# Altium schematic sheet USB_RF_CC1101.SchDoc(USB_RF_CC1101)
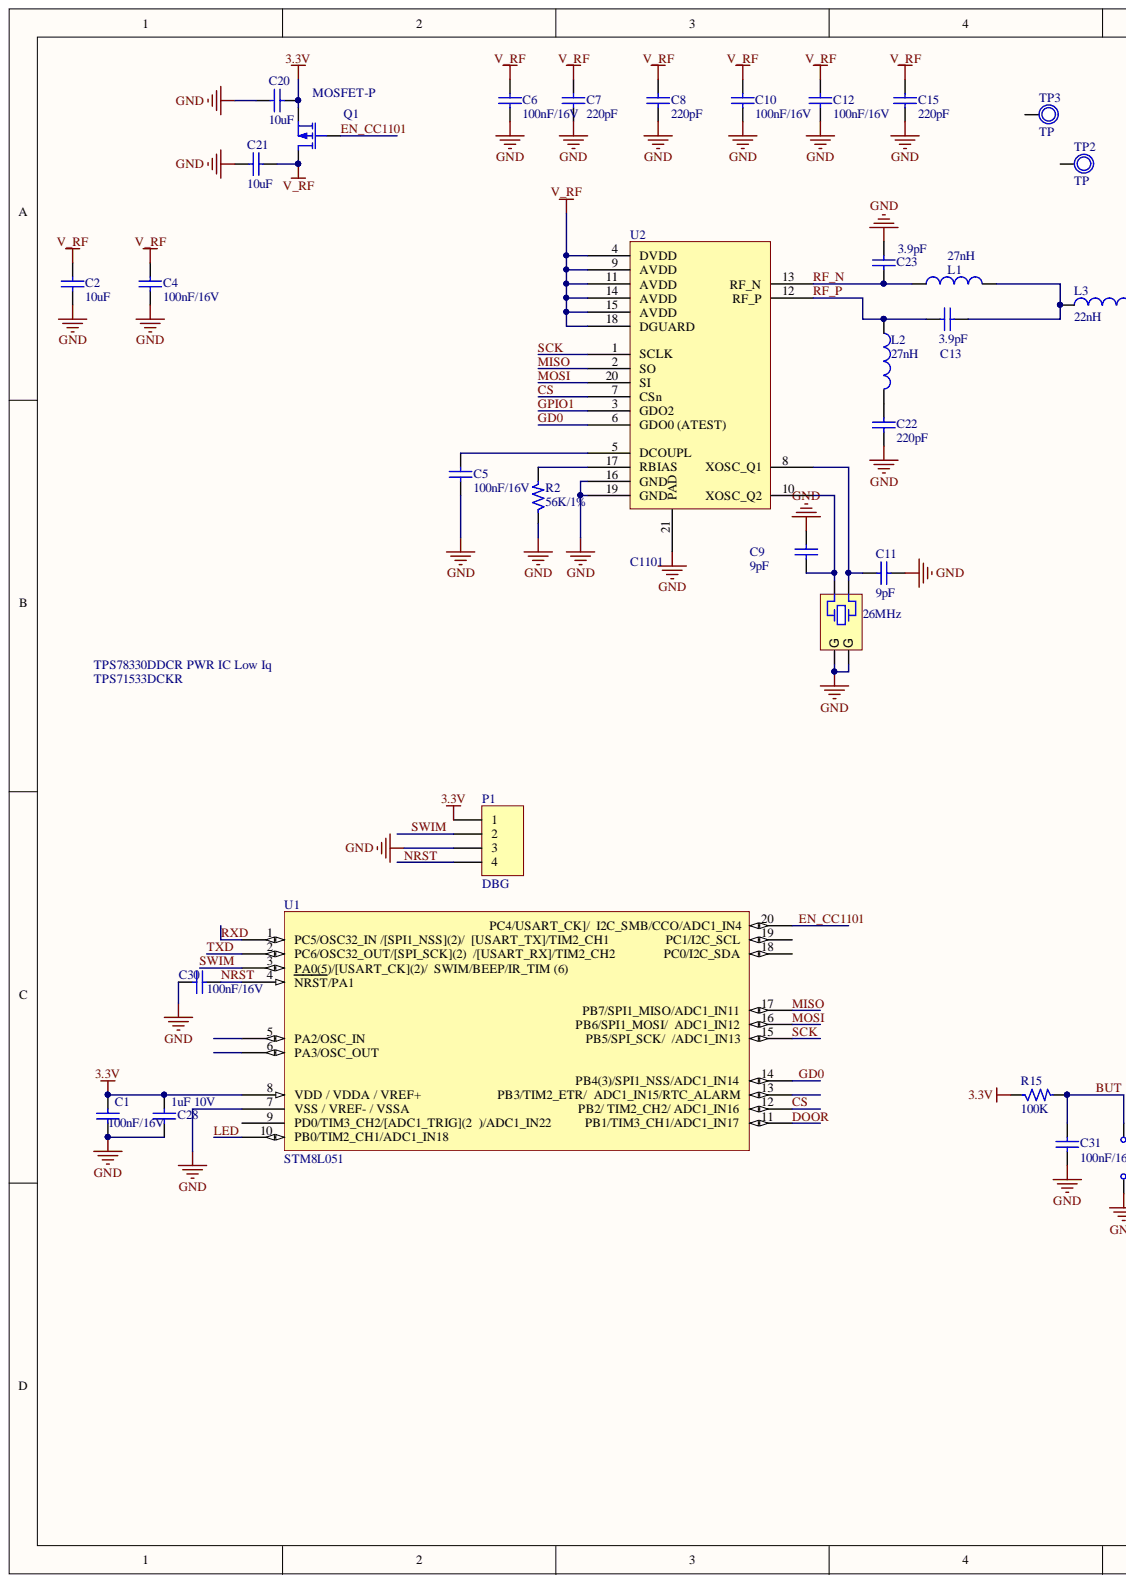
[diagram p0: USB_RF_CC1101.SchDoc, left]
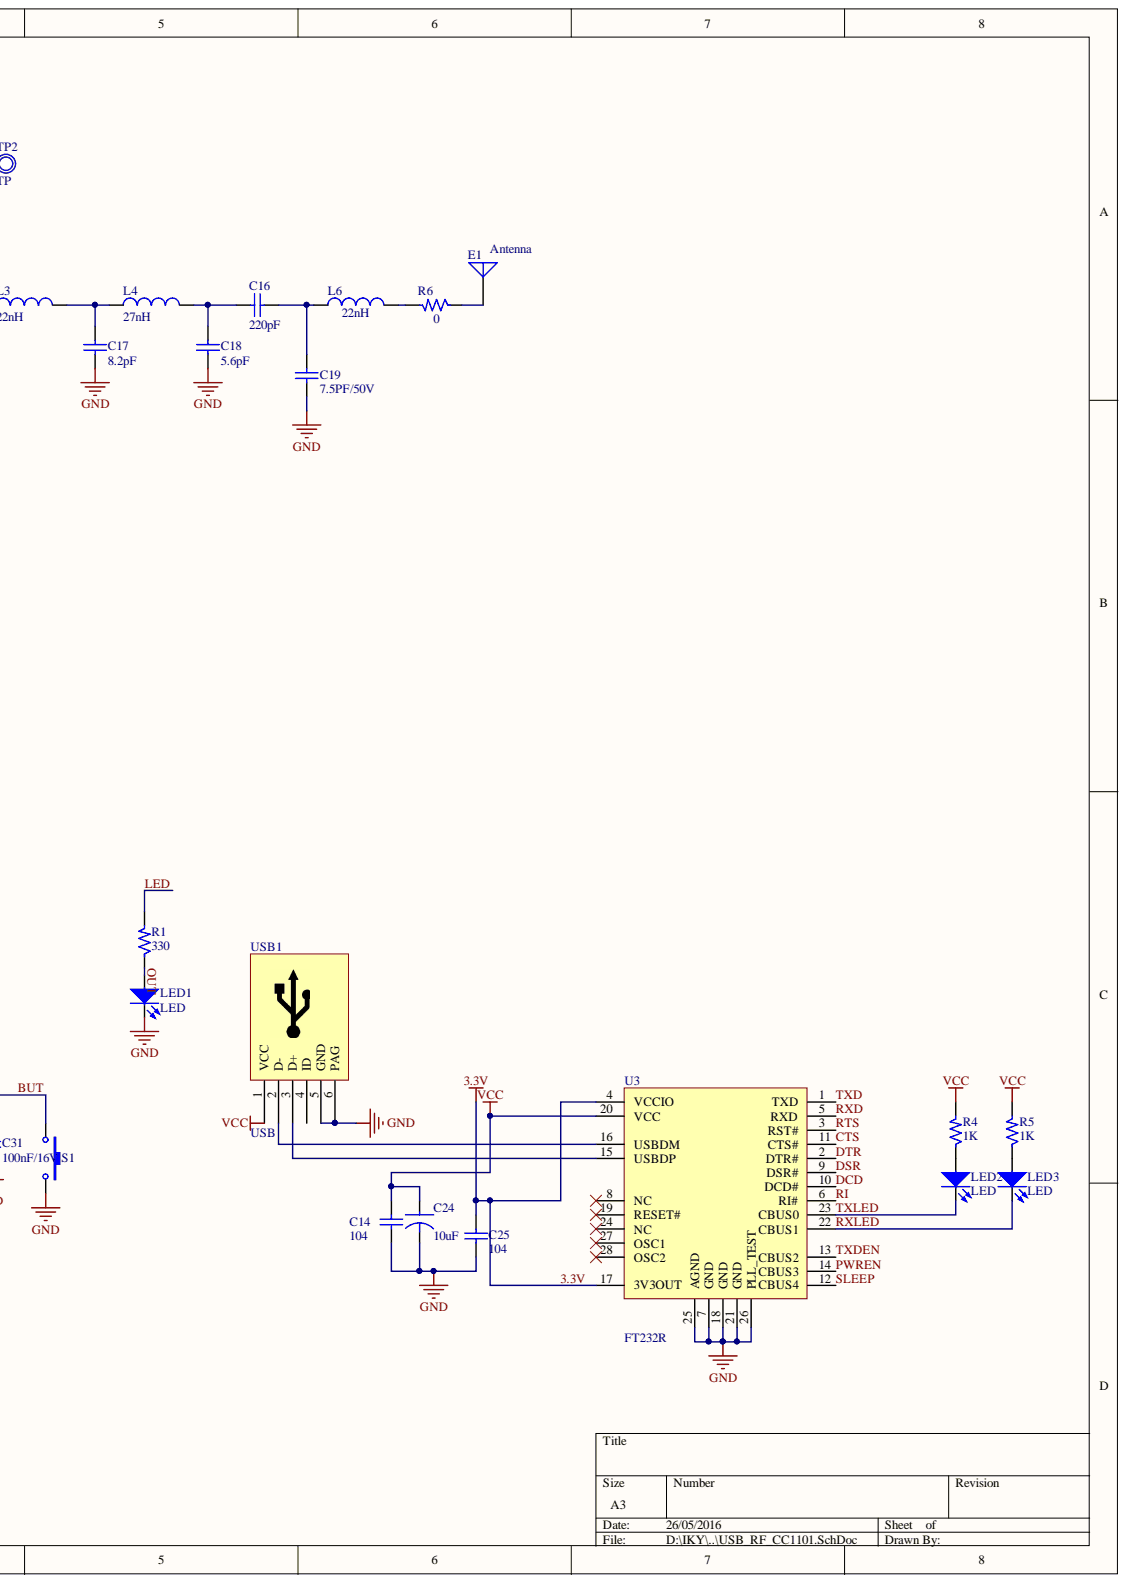
[diagram p1: USB_RF_CC1101.SchDoc, right]

## Parts
C1  100nF/16V  [CAP SMD 0402.CVH]
C2  10uF  [CAP SMD 0603.tdx]
C4  100nF/16V  [CAP SMD 0402 TI.TDX]
C5  100nF/16V  [CAP SMD 0402 TI.TDX]
C6  100nF/16V  [CAP SMD 0402 TI.TDX]
C7  220pF  [CAP SMD 0402 TI.TDX]
C8  220pF  [CAP SMD 0402 TI.TDX]
C9  9pF  [CAP SMD 0402 TI.TDX]
C10  100nF/16V  [CAP SMD 0402 TI.TDX]
C11  9pF  [CAP SMD 0402 TI.TDX]
C12  100nF/16V  [CAP SMD 0402 TI.TDX]
C13  3.9pF  [CAP SMD 0402 TI.TDX]
C14  104  [CAP SMD 0402.tdx]
C15  220pF  [CAP SMD 0402 TI.TDX]
C16  220pF  [CAP SMD 0402 TI.TDX]
C17  8.2pF  [CAP SMD 0402 TI.TDX]
C18  5.6pF  [CAP SMD 0402 TI.TDX]
C19  7.5PF/50V  [CAP SMD 0402 TI.TDX]
C20  10uF  [CAP SMD 0603.tdx]
C21  10uF  [CAP SMD 0603.tdx]
C22  220pF  [CAP SMD 0402 TI.TDX]
C23  3.9pF  [CAP SMD 0402 TI.TDX]
C24  10uF  [CAP SMD 0805.CVH]
C25  104  [CAP SMD 0402.tdx]
C28  1uF 10V  [CAP SMD 0805.CVH]
C30  100nF/16V  [CAP SMD 0402.CVH]
C31  100nF/16V  [CAP SMD 0402.CVH]
E1  Antenna  [Anten 433MHZ PULSE.TDX]
L1  27nH  [INDUCTOR SMD 0402 TI.TDX]
L2  27nH  [INDUCTOR SMD 0402 TI.TDX]
L3  22nH  [INDUCTOR SMD 0402 TI.TDX]
L4  27nH  [INDUCTOR SMD 0402 TI.TDX]
L6  22nH  [INDUCTOR SMD 0402 TI.TDX]
LED1  LED  [LED SMD 0603 RED.CVH]
LED2  LED  [LED SMD 0805 GRE.CVH]
LED3  LED  [LED SMD 0805 RED.CVH]
P1  DBG  [HEADER 4PIN.TDX]
Q1  MOSFET-P  [SOT23-3.CVH]
R1  330  [RESISTOR SMD 0402.CVH]
R2  56K/1%  [RESISTOR SMD 0402 TI.TDX]
R4  1K  [RESISTOR SMD 0402.tdx]
R5  1K  [RESISTOR SMD 0402.tdx]
R6  0  [RESISTOR SMD 0402.CVH]
R15  100K  [RESISTOR SMD 0402.CVH]
S1  SW  [BUTTON SMD 4PIN_PTS810.TDX]  (value not drawn)
TP2  TP  [TEST POINT SMD.CVH]
TP3  TP  [TEST POINT SMD.CVH]
U1  STM8L051  [TSSOP 20.CVH]
U2  C1101  [QFN-20_CC1101.TDX]
U3  FT232R  [SSOP 28.CVH]
USB1  USB  [USB MINI SMD.CVH]
Y1  26MHz  [CRYSTAL SMD 4 PIN 3.2×2.5×0.75.TDX]

## Nets
GND: C1.2 C2.2 C4.2 C5.1 C6.2 C7.2 C8.2 C9.2 C10.2 C11.1 C12.2 C14.1 C15.2 C17.1 C18.1 C19.2 C20.1 C21.2 C22.1 C23.1 C24.2 C25.2 C28.1 C30.2 C31.2 LED1.2 P1.3 R2.1 S1.2 U1.7(VSS_/_VREF-_/_VSSA) U2.16(GND) U2.19(GND) U2.21(PAD) U3.7(GND) U3.18(GND) U3.21(GND) U3.25(AGND) U3.26(PLL_TEST) USB1.5(GND) USB1.6(PAG) Y1.2(G) Y1.4(G)
V_RF: C2.1 C4.1 C6.1 C7.1 C8.1 C10.1 C12.1 C15.1 C21.1 Q1.3 U2.4(DVDD) U2.9(AVDD) U2.11(AVDD) U2.14(AVDD) U2.15(AVDD) U2.18(DGUARD)
VCC: C14.2 C24.1 R4.2 R5.2 U3.20(VCC) USB1.1(VCC)
3.3V: C1.1 C20.2 C25.1 C28.2 P1.1 Q1.2 R15.1 U1.8(VDD_/_VDDA_/_VREF+) U3.4(VCCIO) U3.17(3V3OUT)
BUT: C31.1 R15.2 S1.1
CS: U1.12(PB2/_TIM2_CH2/_ADC1_IN16) U2.7(CSn)
CTS: U3.11(CTS#)
DCD: U3.10(DCD#)
DOOR: U1.11(PB1/TIM3_CH1/ADC1_IN17)
DSR: U3.9(DSR#)
DTR: U3.2(DTR#)
EN_CC1101: Q1.1 U1.20(I2C_SMB/CCO/ADC1_IN4)
GD0: U1.14(PB4(3)/SPI1_NSS/ADC1_IN14) U2.6(GDO0_(ATEST))
GPIO1: U2.3(GDO2)
LED: R1.2 U1.10(PB0/TIM2_CH1/ADC1_IN18)
MISO: U1.17(PB7/SPI1_MISO/ADC1_IN11) U2.2(SO)
MOSI: U1.16(ADC1_IN12) U2.20(SI)
NRST: C30.1 P1.4 U1.4(NRST/PA1)
OUT: LED1.1 R1.1
PWREN: U3.14(CBUS3)
RF_N: C23.2 L1.1 U2.13(RF_N)
RF_P: C13.2 L2.1 U2.12(RF_P)
RI: U3.6(RI#)
RTS: U3.3(RST#)
RXD: U1.1(PC5/OSC32_IN_/[SPI1_NSS](2)/) U3.5(RXD)
RXLED: LED3.2 U3.22(CBUS1)
SCK: U1.15(/ADC1_IN13) U2.1(SCLK)
SLEEP: U3.12(CBUS4)
SWIM: P1.2 U1.3(PA0(5)/[USART_CK](2)/)
TXD: U1.2(PC6/OSC32_OUT/[SPI_SCK](2)) U3.1(TXD)
TXDEN: U3.13(CBUS2)
TXLED: LED2.2 U3.23(CBUS0)
NetC5_2: C5.2 U2.5(DCOUPL)
NetC9_1: C9.1 U2.10(XOSC_Q2) Y1.1
NetC11_2: C11.2 U2.8(XOSC_Q1) Y1.3
NetC13_1: C13.1 L1.2 L3.1
NetC16_1: C16.1 C19.1 L6.1
NetC16_2: C16.2 C18.2 L4.2
NetC17_2: C17.2 L3.2 L4.1
NetC22_2: C22.2 L2.2
NetE1_1: E1.1 R6.1
NetL6_2: L6.2 R6.2
NetLED2_1: LED2.1 R4.1
NetLED3_1: LED3.1 R5.1
NetR2_2: R2.2 U2.17(RBIAS)
NetU3_15: U3.15(USBDP) USB1.3(D+)
NetU3_16: U3.16(USBDM) USB1.2(D-)

Not connected: TP2 1; TP3 1; U1 5(PA2/OSC_IN) 6(PA3/OSC_OUT) 9(PD0/TIM3_CH2/[ADC1_TRIG](2) 13(ADC1_IN15/RTC_ALARM) 18(PC0/I2C_SDA) 19(PC1/I2C_SCL); U3 8(NC) 19(RESET#) 24(NC) 27(OSC1) 28(OSC2); USB1 4(ID)

## Library data of the parts
C1 C2 C4-C23 C25 C30 C31 = Capacitor NP: Ceramic capacitor; Manufacturer: Yageo; Value: 100nF/50V; Component Kind: Standard; Publisher: Cao Van Huong, vanhuongcao@gmail.com
  C1 C30 C31: Library Name: Altium Library.CVH.SchLib
  C2 C4-C13 C15-C23: Library Name: Altium Library.CVH.SchLib
  C14 C25: Library Name: Altium Library.CVH-TOANDINHXUAN-PC.SchLib
C24 = Capacitor: Alumium capacitor; Component Kind: Standard; Library Name: Altium Library.CVH-TOANDINHXUAN-PC.SchLib; Publisher: Cao Van Huong, vanhuongcao@gmail.com
C28 = 10uF-10V-C0805: CAP CER 10UF 10V 10% X5R 0805; Manufacturer: Kemet; Manufacturer Part Number: C0805C106K8PACTU; Component Kind: Standard; Digi-Key Part Number: 399-4925-2-ND; Library Name: Capacitor.SchLib
E1 = Antenna: Generic Antenna; Component Kind: Standard; LatestRevisionDate: 17-Jul-2002; LatestRevisionNote: Re-released for DXP Platform.; Library Name: Miscellaneous Devices.IntLib; PackageReference: PIN1; Published: 24-Mar-1999; Publisher: Altium Limited
L1-L4 L6 = Inductor: Value: 150 mH; Component Kind: Standard; Description: Inductor; Library Name: Altium Library.CVH.SchLib; Publisher: Cao Van Huong, vanhuongcao@gmail.com
LED1-LED3 = LED: LED Single; Value: LED 3 ly Single Colour; Component Kind: Standard; Publisher: Cao Van Huong, vanhuongcao@gmail.com
  LED1: Library Name: Altium Library.CVH.SchLib
  LED2 LED3: Library Name: Altium Library.CVH-TOANDINHXUAN-PC.SchLib
P1 = Header 1X4: Header, 4-Pin; Component Kind: Standard; Library Name: tranhieu_hcmut.SchLib
Q1 = MOSFET-P: P-Channel MOSFET; Component Kind: Standard; Library Name: Altium Library.CVH-TOANDINHXUAN-PC.SchLib; Publisher: Cao Van Huong, vanhuongcao@gmail.com
R1 R2 R4-R6 R15 = Resistor: RESISTOR, THICK FILM, 2.7k, -1%/+1%, 0.063W, 50V, -55DEGC/+155DEGC, 0805, SMD; Manufacturer: Yageo; Value: 10K; Component Kind: Standard; PackageReference: R0805; Publisher: Cao Van Huong, vanhuongcao@gmail.com
  R1 R6 R15: Library Name: Altium Library.CVH.SchLib
  R2: Library Name: Altium Library.CVH.SchLib
  R4 R5: Library Name: Altium Library.CVH-TOANDINHXUAN-PC.SchLib
S1 = SW-Button: Switch-Button; Component Kind: Standard; Library Name: Altium Library.CVH.SchLib; Publisher: Cao Van Huong, vanhuongcao@gmail.com
TP2 TP3 = Test Point: Component Kind: Standard; Description: Test Point; Library Name: Altium Library.CVH.SchLib; Value: Test Point
U1 = STM8L051F3: Value line, 16 MHz STM8S 8-bit MCU, 8 Kbytes Flash, 128 bytes  data EEPROM, 10-bit ADC, 3 timers, UART, SPI, I²C; Manufacturer: STM; Manufacturer P/N: STM8S003F3P6TR; Value: STM8S003F3P6; Component Kind: Standard; Library Name: Altium Library.CVH.SchLib; PackageReference: TSSOP 20; Publisher: Cao Van Huong, vanhuongcao@gmail.com
U2 = CC1101: Low-Power Sub-1 GHz RF Transceiver; Manufacturer: Texas Instruments; Manufacturer P/N: CC1101RGPR; Component Kind: Standard; PackageReference: QFN20; Value: C1101; Library Name: Altium Library.CVH.SchLib; Publisher: Cao Van Huong, vanhuongcao@gmail.com
U3 = FT232R: FT232R USB to RS-232 Bridge Controller; Component Kind: Standard; Library Name: Altium Library.CVH-TOANDINHXUAN-PC.SchLib; Publisher: Cao Van Huong, vanhuongcao@gmail.com
USB1 = USB MINI: USB Mini connector; Component Kind: Standard; Library Name: Altium Library.CVH-TOANDINHXUAN-PC.SchLib; Publisher: Cao Van Huong, vanhuongcao@gmail.com
Y1 = XTAL 4pin: Crystal Oscillator; Component Kind: Standard; Library Name: Altium Library.CVH.SchLib; Publisher: Cao Van Huong, vanhuongcao@gmail.com
